# Altium schematic sheet Supply.SchDoc(Supply)
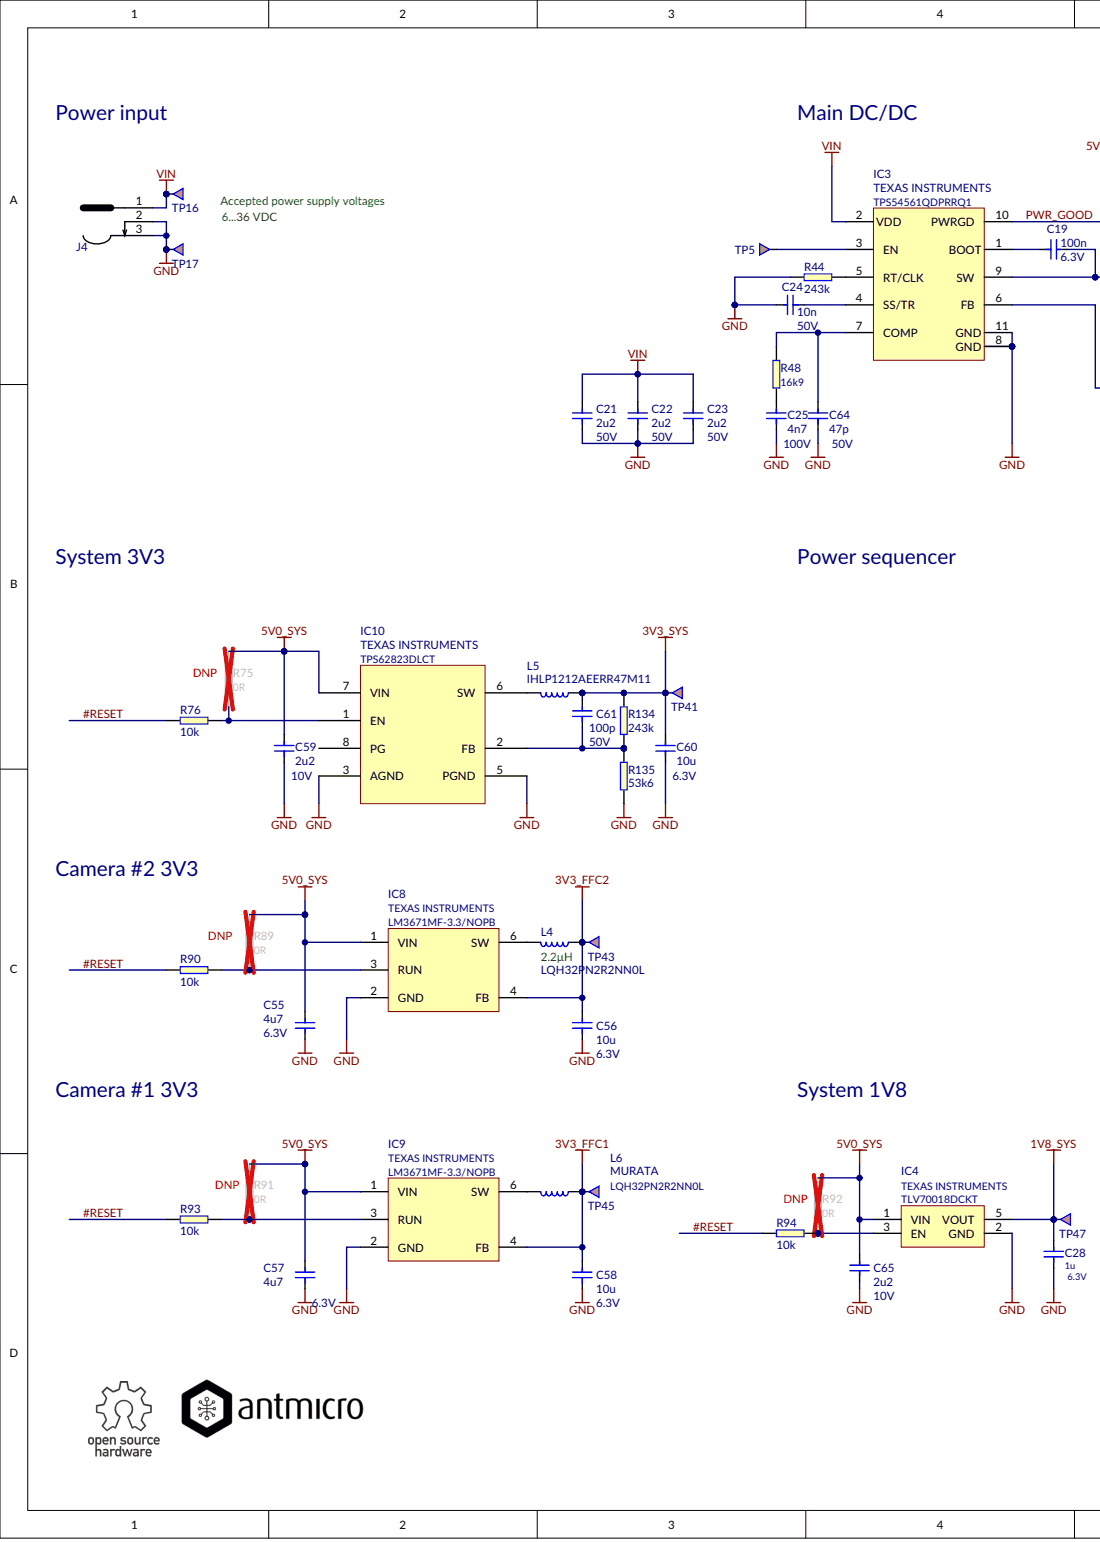
[diagram p0: Supply.SchDoc, left]
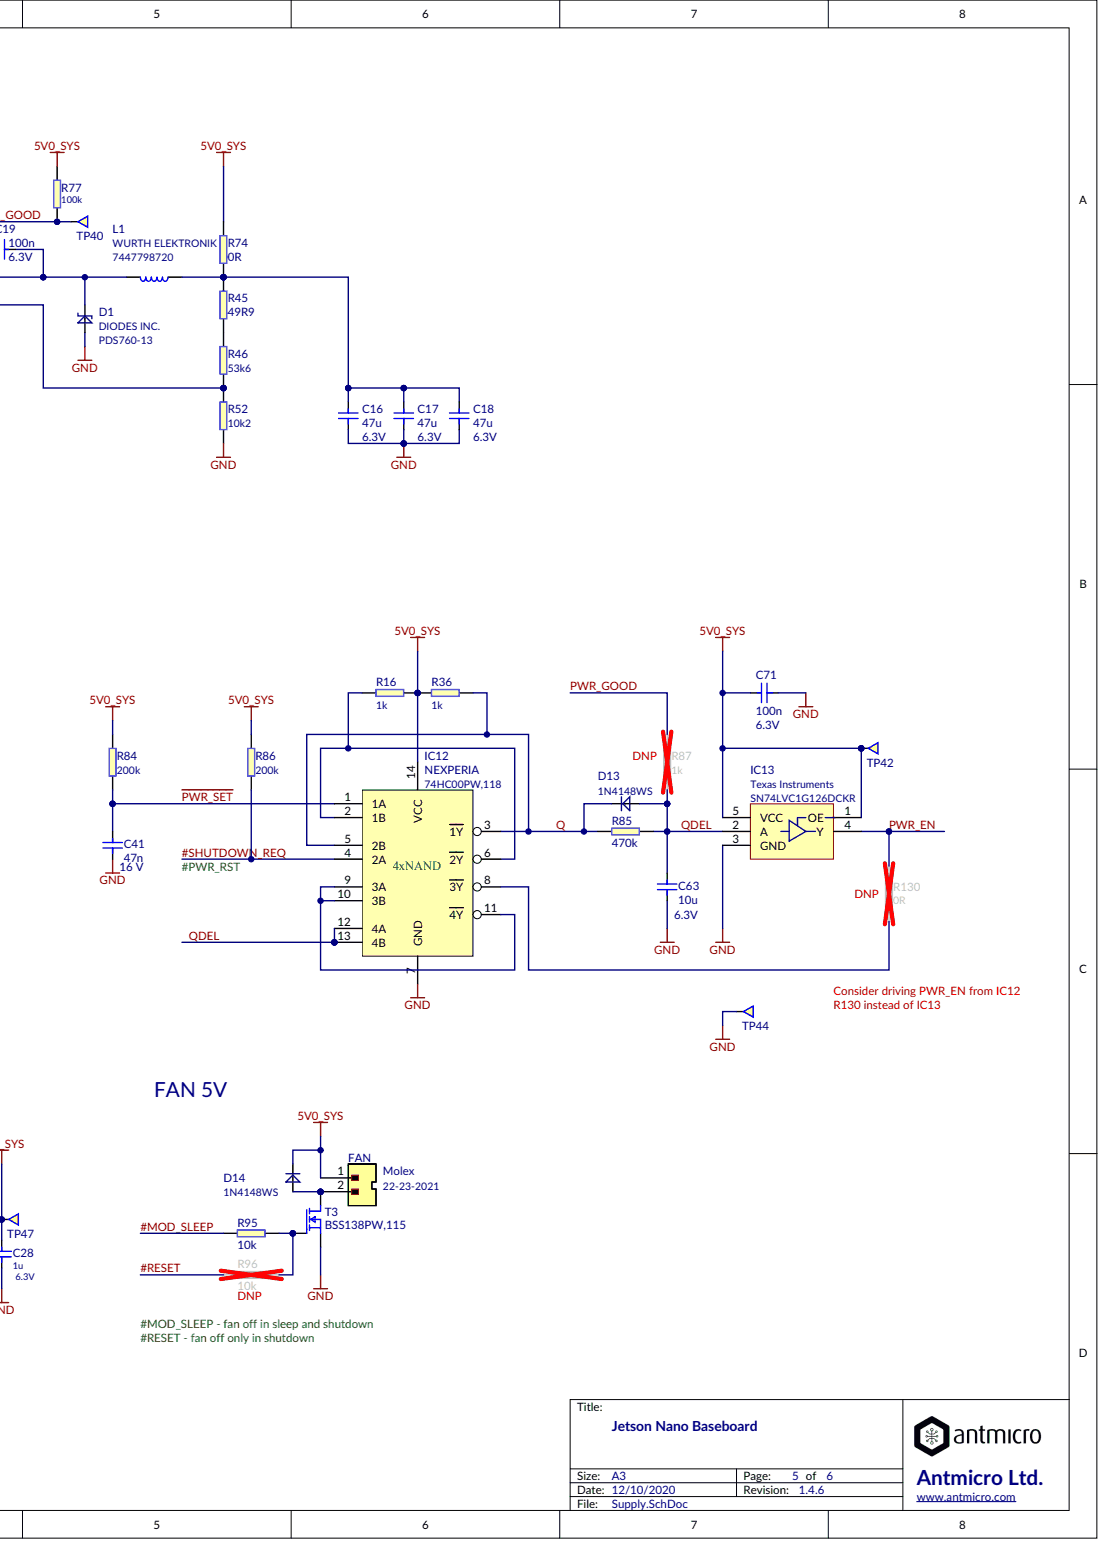
[diagram p1: Supply.SchDoc, right]

## Parts
C16  Voltage: 6.3V  (hidden comment: 47u)
C17  Voltage: 6.3V  (hidden comment: 47u)
C18  Voltage: 6.3V  (hidden comment: 47u)
C19  Voltage: 6.3V  (hidden comment: 100n)
C21  Voltage: 50V  (hidden comment: 2u2)
C22  Voltage: 50V  (hidden comment: 2u2)
C23  Voltage: 50V  (hidden comment: 2u2)
C24  Voltage: 50V  (hidden comment: 10n)
C25  Voltage: 100V  (hidden comment: 4n7)
C28  Voltage: 6.3V  (hidden comment: 1u)
C41  Voltage: 16 V  (hidden comment: 47n)
C55  Voltage: 6.3V  (hidden comment: 4u7)
C56  Voltage: 6.3V  (hidden comment: 10u)
C57  Voltage: 6.3V  (hidden comment: 4u7)
C58  Voltage: 6.3V  (hidden comment: 10u)
C59  Voltage: 10V  (hidden comment: 2u2)
C60  Voltage: 6.3V  (hidden comment: 10u)
C61  Voltage: 50V  (hidden comment: 100p)
C63  Voltage: 6.3V  (hidden comment: 10u)
C64  Voltage: 50V  (hidden comment: 47p)
C65  Voltage: 10V  (hidden comment: 2u2)
C71  Voltage: 6.3V  (hidden comment: 100n)
D1  PDS760-13
D13  1N4148WS
D14  1N4148WS
FAN  Manufacturer: Molex  MPN: 22-23-2021  (hidden comment: MX-22-23-2021)
IC3  Manufacturer: TEXAS INSTRUMENTS  (hidden comment: TPS54561QDPRRQ1)
IC4  Manufacturer: TEXAS INSTRUMENTS  (hidden comment: TLV70018DCKT)
IC8  Manufacturer: TEXAS INSTRUMENTS  MPN: LM3671MF-3.3/NOPB  (hidden comment: LM3671MF-3.3)
IC9  Manufacturer: TEXAS INSTRUMENTS  MPN: LM3671MF-3.3/NOPB  (hidden comment: LM3671MF-3.3)
IC10  Manufacturer: TEXAS INSTRUMENTS  (hidden comment: TPS62823DLCT)
IC12  Manufacturer: NEXPERIA  (hidden comment: 74HC00PW,118)
IC13  Manufacturer: Texas Instruments  (hidden comment: SN74LVC1G126DCKR)
J4  PJ-002AH  (value not drawn)
L1  7447798720
L4  LQH32PN2R2NN0L  (value not drawn)
L5  IHLP1212AEERR47M11
L6  Manufacturer: MURATA  (hidden comment: LQH32PN2R2NN0L)
R16  1k
R36  1k
R44  243k
R45  49R9
R46  53k6
R48  16k9
R52  10k2
R74  0R
R75  0R
R76  10k
R77  100k
R84  200k
R85  470k
R86  200k
R87  1k
R89  0R
R90  10k
R91  0R
R92  0R
R93  10k
R94  10k
R95  10k
R96  10k
R130  0R
R134  243k
R135  53k6
T3  BSS138PW,115
TP5  Testpad  (value not drawn)
TP16  Testpad  (value not drawn)
TP17  Testpad  (value not drawn)
TP40  Testpad  (value not drawn)
TP41  Testpad  (value not drawn)
TP42  Testpad  (value not drawn)
TP43  Testpad  (value not drawn)
TP44  Testpad  (value not drawn)
TP45  Testpad  (value not drawn)
TP47  Testpad  (value not drawn)

## Nets
1V8_SYS: C28.2 IC4.5(VOUT) TP47.1
3V3_FFC1: C58.2 IC9.4(FB) L6.2 TP45.1
3V3_FFC2: C56.2 IC8.4(FB) L4.2 TP43.1
3V3_SYS: C60.2 C61.2 L5.2 R134.1 TP41.1
5V0_SYS: C55.2 C57.2 C59.2 C65.2 C71.2 D14.C FAN.1 IC4.1(VIN) IC8.1(VIN) IC9.1(VIN) IC10.7(VIN) IC12.14(VCC) IC13.1(OE) IC13.5(VCC) R16.1 R36.2 R74.2 R75.2 R77.2 R84.2 R86.2 R89.2 R91.2 R92.1 TP42.1
GND: C16.1 C17.1 C18.1 C21.1 C22.1 C23.1 C24.2 C25.1 C28.1 C41.1 C55.1 C56.1 C57.1 C58.1 C59.1 C60.1 C63.1 C64.1 C65.1 C71.1 D1.A IC3.8(GND) IC3.11(GND) IC4.2(GND) IC8.2(GND) IC9.2(GND) IC10.3(AGND) IC10.5(PGND) IC12.7(GND) IC13.3(GND) J4.2 J4.3 R44.2 R52.1 R135.1 T3.2 TP17.1 TP44.1
VIN: C21.2 C22.2 C23.2 IC3.2(VDD) J4.1 TP16.1
#MOD_SLEEP: R95.2
#RESET: R76.2 R90.2 R93.2 R94.2 R96.2
#SHUTDOWN_REQ: IC12.4(2A) R86.1
~{PWR_SET}: C41.2 IC12.1(1A) R84.1
PWR_EN: IC13.4(Y) R130.1
PWR_GOOD: IC3.10(PWRGD) R77.1 R87.1 TP40.1
Q: D13.C IC12.3(1Y) IC12.5(2B) R36.1 R85.1
QDEL: C63.2 D13.A IC12.12(4A) IC12.13(4B) IC13.2(A) R85.2 R87.2
NetC16_2: C16.2 C17.2 C18.2 L1.2 R45.2 R74.1
NetC19_1: C19.1 IC3.1(BOOT)
NetC19_2: C19.2 D1.C IC3.9(SW) L1.1
NetC24_1: C24.1 IC3.4(SS/TR)
NetC25_2: C25.2 R48.1
NetC61_1: C61.1 IC10.2(FB) R134.2 R135.2
NetC64_2: C64.2 IC3.7(COMP) R48.2
NetD14_A: D14.A FAN.2 T3.3
NetIC3_3: IC3.3(EN) TP5.1
NetIC3_5: IC3.5(RT/CLK) R44.1
NetIC3_6: IC3.6(FB) R46.1 R52.2
NetIC4_3: IC4.3(EN) R92.2 R94.1
NetIC8_3: IC8.3(RUN) R89.1 R90.1
NetIC8_6: IC8.6(SW) L4.1
NetIC9_3: IC9.3(RUN) R91.1 R93.1
NetIC9_6: IC9.6(SW) L6.1
NetIC10_1: IC10.1(EN) R75.1 R76.1
NetIC10_6: IC10.6(SW) L5.1
NetIC12_2: IC12.2(1B) IC12.6(2Y) R16.2
NetIC12_8: IC12.8(3Y) R130.2
NetIC12_9: IC12.9(3A) IC12.10(3B) IC12.11(4Y)
NetR45_1: R45.1 R46.2
NetR95_1: R95.1 R96.1 T3.1

Not connected: IC10 8(PG)

## Library data of the parts
C16-C18 = (no symbol name): PartNumber: C_47u_0805; Manufacturer: KEMET; MPN: C0805C476M9PACTU; Value: 47u
C19 C71 = (no symbol name): Manufacturer: TDK; PartNumber: C_100n_0402; MPN: C1005X5R0J104K050BA; Value: 100n
C21-C23 = (no symbol name): PartNumber: C_2u2_1206; Manufacturer: KEMET; MPN: C1206C225J5RACTU; Value: 2u2
C24 = (no symbol name): PartNumber: C_10n_0402; Manufacturer: AVX; MPN: 04025G103ZAT2A; Value: 10n
C25 = (no symbol name): PartNumber: C_4n7_0402; Manufacturer: TDK; MPN: CGA2B3X7S2A472K050BB; Value: 4n7
C28 = (no symbol name): PartNumber: C_1u_0402; Manufacturer: MULTICOMP; MPN: MC0402F105Z6R3CT; Value: 1u
C41 = (no symbol name): PartNumber: C_47n_0402; Manufacturer: MULTICOMP; MPN: MC0402X473K160CT; Value: 47n
C55 C57 = (no symbol name): PartNumber: C_4u7_0402; Manufacturer: MURATA; MPN: GRM155R60J475ME87D; Value: 4u7
C56 C58 C60 C63 = (no symbol name): Manufacturer: Walsin; PartNumber: C_10u_0603; MPN: 0603X106M6R3CT; Value: 10u
C59 C65 = (no symbol name): Manufacturer: TDK; PartNumber: C_2u2_0402; MPN: C1005X5R1A225K050BC; Value: 2u2
C61 = (no symbol name): PartNumber: C_100p_0402; Manufacturer: WALSIN; MPN: 0402N101J500CT; Value: 100p
C64 = (no symbol name): PartNumber: C_47p_0402; Manufacturer: KEMET; MPN: C0402C470J5GACTU; Value: 47p
D1 = (no symbol name): Manufacturer: DIODES INC.; PartNumber: PDS760-13; Value: PDS760-13; MPN: PDS760-13
D13 D14 = (no symbol name): Manufacturer: ON SEMICONDUCTOR; PartNumber: 1N4148WS; Value: 1N4148WS; MPN: 1N4148WS
FAN = (no symbol name): PartNumber: molex_2223-2021; Value: MX-22-23-2021
J4 = (no symbol name): Manufacturer: CUI; PartNumber: PJ-002AH; Value: PJ-002AH; MPN: PJ-002AH
L1 = (no symbol name): Manufacturer: WURTH ELEKTRONIK; PartNumber: 7447798720; Value: 7447798720; MPN: 7447798720
L4 = (no symbol name): Manufacturer: MURATA; PartNumber: LQH32PN2R2NN0L; Value: LQH32PN2R2NN0L; MPN: LQH32PN2R2NN0L
L5 = (no symbol name): Manufacturer: VISHAY; PartNumber: IHLP1212AEERR47M11; Value: IHLP1212AEERR47M11; MPN: IHLP1212AEERR47M11
R16 R36 R87 = (no symbol name): PartNumber: R_1k_0402; Manufacturer: BOURNS; MPN: CR0402-FX-1001GLF; Value: 1k
R44 R134 = (no symbol name): PartNumber: R_243k_0402; Manufacturer: YAGEO; MPN: RC0402FR-07243KL; Value: 243k
R45 = (no symbol name): PartNumber: R_49R9_0402; Manufacturer: VISHAY; MPN: CRCW040249R9FKEDC; Value: 49R9
R46 R135 = (no symbol name): PartNumber: R_53k6_0402; Manufacturer: TE CONECTIVITY; MPN: CPF0402B53K6E1; Value: 53k6
R48 = (no symbol name): PartNumber: R_16k9_0402; Manufacturer: Panasonic; MPN: ERA2AEB1692X; Value: 16k9
R52 = (no symbol name): PartNumber: R_10k2_0402_0.1%; Manufacturer: TE CONECTIVITY; MPN: CPF0402B10K2E1; Value: 10k2
R74 = (no symbol name): PartNumber: R_0R_0805; Manufacturer: BOURNS; MPN: CR0805-J/-000ELF; Value: 0R
R75 R89 R91 R92 R130 = (no symbol name): PartNumber: R_0R_0402; Manufacturer: Panasonic; MPN: ERJ2GE0R00X; Value: 0R
R76 R90 R93-R96 = (no symbol name): PartNumber: R_10k_0402; Manufacturer: PANASONIC; MPN: ERJ-2RKF1002X; Value: 10k
R77 = (no symbol name): PartNumber: R_100k_0402; Manufacturer: VISHAY; MPN: CRCW0402100KFKEDHP; Value: 100k
R84 R86 = (no symbol name): PartNumber: R_200k_0402; Manufacturer: YAGEO; MPN: RC0402FR-07200KL; Value: 200k
R85 = (no symbol name): PartNumber: R_470k_0402; Manufacturer: VISHAY; MPN: CRCW0402470KFKED; Value: 470k
T3 = (no symbol name): Manufacturer: NXP; Value: BSS138PW,115; PartNumber: BSS138PW,115; MPN: BSS138PW,115
TP5 TP16 TP17 TP40-TP45 TP47 = (no symbol name): PartNumber: TP-R-1.5
IC3 IC4 IC8-IC10 IC12 IC13 L6 = (no symbol name)
  IC3: PartNumber: TPS54561QDPRRQ1; Value: TPS54561QDPRRQ1; MPN: TPS54561QDPRRQ1
  IC4: Value: TLV70018DCKT; PartNumber: TLV70018DCKT; MPN: TLV70018DCKT
  IC8 IC9: Value: LM3671MF-3.3; PartNumber: LM3671MF-3.3
  IC10: PartNumber: TPS62823; Value: TPS62823DLCT; MPN: TPS62823DLCT
  IC12: PartNumber: 74HC00PW,118; Value: 74HC00PW,118; MPN: 74HC00PW,118
  IC13: PartNumber: SN74LVC1G126DCKR; Value: SN74LVC1G126DCKR; MPN: SN74LVC1G126DCKR
  L6: PartNumber: LQH32PN2R2NN0L; Value: LQH32PN2R2NN0L; MPN: LQH32PN2R2NN0L
